# Altium schematic sheet Power.SchDoc
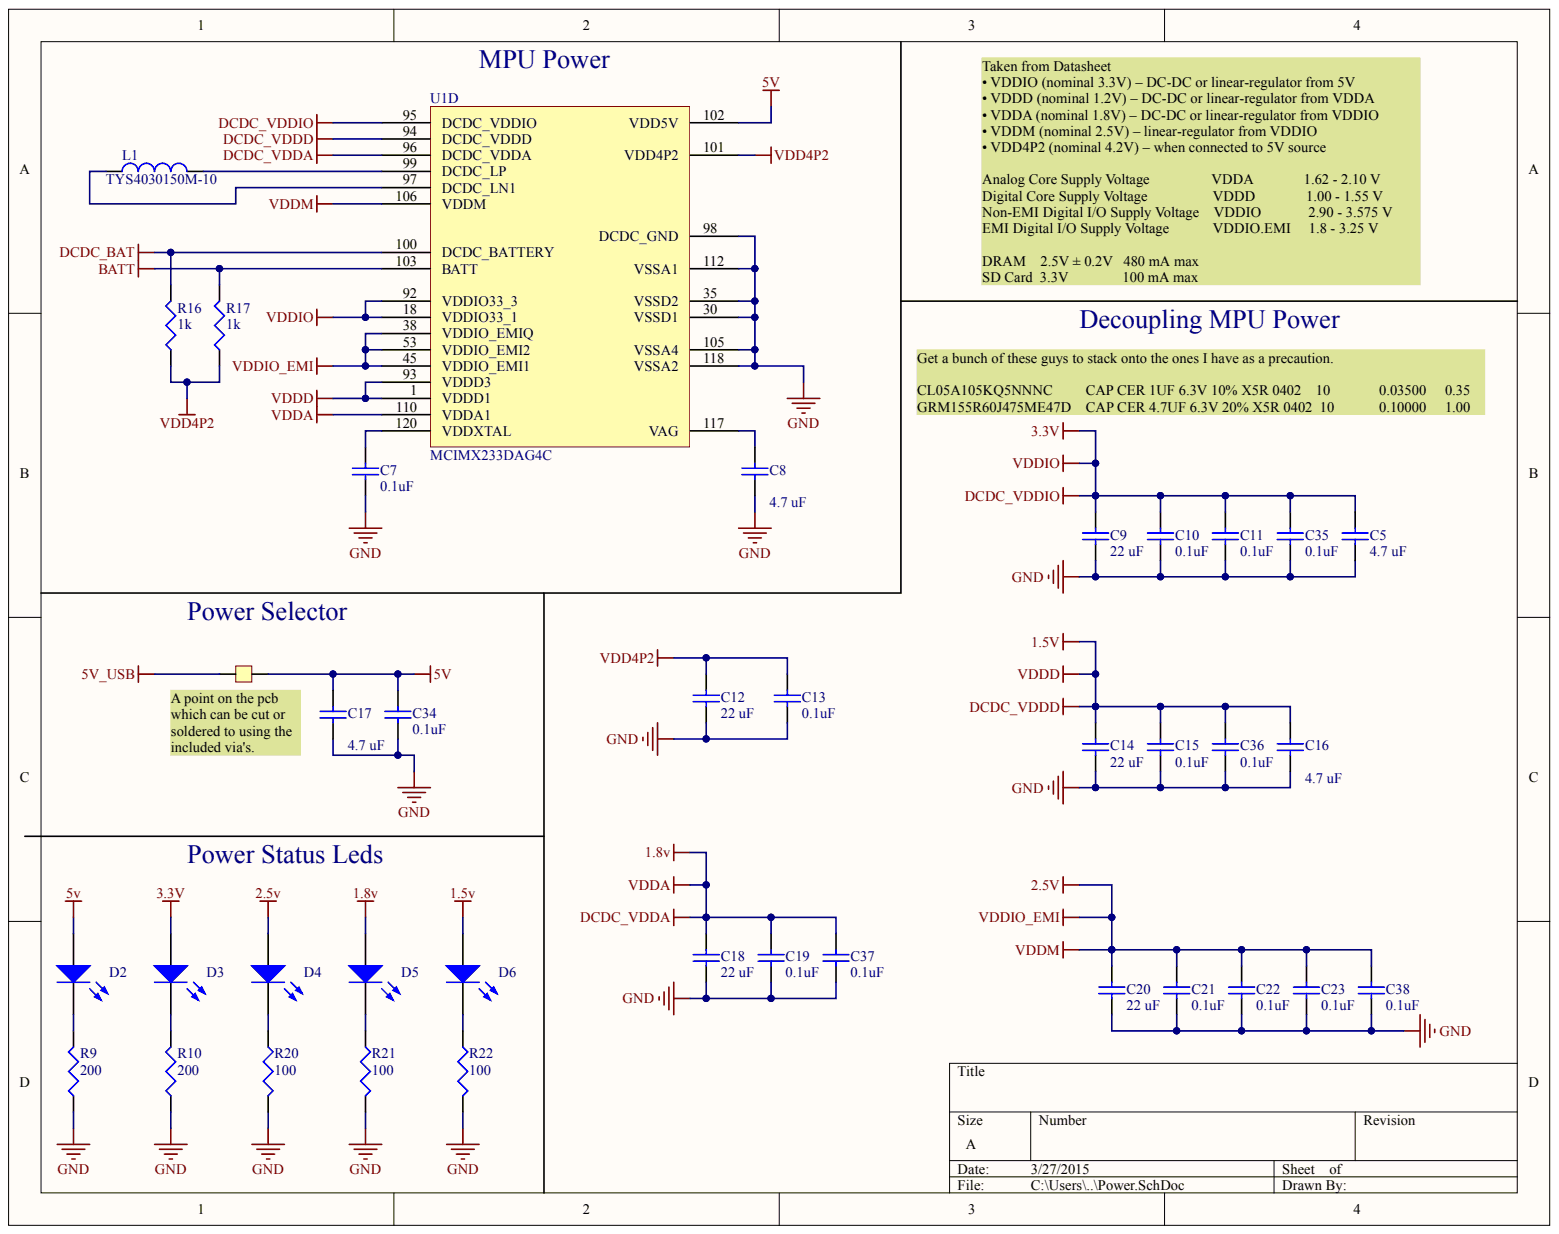
[diagram p0: Power.SchDoc]

## Parts
C5  GRM155R60J475ME47D  value 4.7 uF  [0402]
C7  value 0.1uF  [0402]  (hidden comment: CL05B104KQ5NNNC)
C8  GRM155R60J475ME47D  value 4.7 uF  [0402]
C9  value 22 uF  [0805_GRM21]  (hidden comment: GRM21BR60J226ME39L)
C10  value 0.1uF  [0402]  (hidden comment: CL05B104KQ5NNNC)
C11  value 0.1uF  [0402]  (hidden comment: CL05B104KQ5NNNC)
C12  value 22 uF  [0805_GRM21]  (hidden comment: GRM21BR60J226ME39L)
C13  value 0.1uF  [0402]  (hidden comment: CL05B104KQ5NNNC)
C14  value 22 uF  [0805_GRM21]  (hidden comment: GRM21BR60J226ME39L)
C15  value 0.1uF  [0402]  (hidden comment: CL05B104KQ5NNNC)
C16  GRM155R60J475ME47D  value 4.7 uF  [0402]
C17  GRM155R60J475ME47D  value 4.7 uF  [0402]
C18  value 22 uF  [0805_GRM21]  (hidden comment: GRM21BR60J226ME39L)
C19  value 0.1uF  [0402]  (hidden comment: CL05B104KQ5NNNC)
C20  value 22 uF  [0805_GRM21]  (hidden comment: GRM21BR60J226ME39L)
C21  value 0.1uF  [0402]  (hidden comment: CL05B104KQ5NNNC)
C22  value 0.1uF  [0402]  (hidden comment: CL05B104KQ5NNNC)
C23  value 0.1uF  [0402]  (hidden comment: CL05B104KQ5NNNC)
C34  value 0.1uF  [0402]  (hidden comment: CL05B104KQ5NNNC)
C35  value 0.1uF  [0402]  (hidden comment: CL05B104KQ5NNNC)
C36  value 0.1uF  [0402]  (hidden comment: CL05B104KQ5NNNC)
C37  value 0.1uF  [0402]  (hidden comment: CL05B104KQ5NNNC)
C38  value 0.1uF  [0402]  (hidden comment: CL05B104KQ5NNNC)
D2  LSQ976-Z  [LSQ976-Z]  (value not drawn)
D3  LSQ976-Z  [LSQ976-Z]  (value not drawn)
D4  LSQ976-Z  [LSQ976-Z]  (value not drawn)
D5  LSQ976-Z  [LSQ976-Z]  (value not drawn)
D6  LSQ976-Z  [LSQ976-Z]  (value not drawn)
L1  TYS4030150M-10  [TYS4030150M-10]
P5  CutPointet 2x  [CutPoint-VIA-medium]  (value not drawn)
R9  value 200  [J1-0603]  (hidden comment: MCR03ERTJ201)
R10  value 200  [J1-0603]  (hidden comment: MCR03ERTJ201)
R16  value 1k  [J1-0603]  (hidden comment: MCR03ERTF1001)
R17  value 1k  [J1-0603]  (hidden comment: MCR03ERTF1001)
R20  value 100  [J1-0603]  (hidden comment: RC0603JR-07100RL)
R21  value 100  [J1-0603]  (hidden comment: RC0603JR-07100RL)
R22  value 100  [J1-0603]  (hidden comment: RC0603JR-07100RL)
U1  MCIMX233DAG4C  [TSQFP40P1600X1600X160-128N]  (part D)

## Nets
1.5V: C14.2 C15.1 C16.2 C36.1 D6.A U1.1(VDDD1) U1.93(VDDD3) U1.94(DCDC_VDDD)
1.8V: C18.2 C19.1 C37.1 D5.A U1.96(DCDC_VDDA) U1.110(VDDA1)
2.5V: C20.2 C21.1 C22.1 C23.1 C38.1 D4.A U1.38(VDDIO_EMIQ) U1.45(VDDIO_EMI1) U1.53(VDDIO_EMI2) U1.106(VDDM)
3.3V: C5.2 C9.2 C10.1 C11.1 C35.1 D3.A U1.18(VDDIO33_1) U1.92(VDDIO33_3) U1.95(DCDC_VDDIO)
5V: C17.2 C34.1 D2.A P5.1 U1.102(VDD5V)
5V_USB: P5.2
BATT: R17.2 U1.103(BATT)
DCDC_BAT: R16.2 U1.100(DCDC_BATTERY)
GND: C5.1 C7.2 C8.1 C9.1 C10.2 C11.2 C12.1 C13.2 C14.1 C15.2 C16.1 C17.1 C18.1 C19.2 C20.1 C21.2 C22.2 C23.2 C34.2 C35.2 C36.2 C37.2 C38.2 R9.2 R10.2 R20.2 R21.2 R22.2 U1.30(VSSD1) U1.35(VSSD2) U1.98(DCDC_GND) U1.105(VSSA4) U1.112(VSSA1) U1.118(VSSA2)
VDD4P2: C12.2 C13.1 R16.1 R17.1 U1.101(VDD4P2)
NetC7_1: C7.1 U1.120(VDDXTAL)
NetC8_2: C8.2 U1.117(VAG)
NetD2_C: D2.C R9.1
NetD3_C: D3.C R10.1
NetD4_C: D4.C R20.1
NetD5_C: D5.C R21.1
NetD6_C: D6.C R22.1
NetL1_1: L1.1 U1.97(DCDC_LN1)
NetL1_2: L1.2 U1.99(DCDC_LP)

## Library data of the parts
C5 C8 C16 C17 = GRM155R60J475ME47D: CAP CER 4.7UF 6.3V 20% X5R 0402; Component Kind: Standard; LatestRevisionDate: 29-May-2009; LatestRevisionNote: IPC-7351 Footprint Added.; PackageDescription: Chip Capacitor; PackageReference: C1206; Published: 8-Jun-2000; Signal Integrity: Cap Semi; Simulation: CAP; Supplier 1: Digi-Key; Supplier Part Number 1: 490-5915-1-ND; Library Name: Linux_Board_SCHEM_LIB.SchLib; Publisher: Altium Limited
  C17: Value: 4.7 uF
C7 C10 C11 C13 C15 C19 C21-C23 C34-C38 = CL05B104KQ5NNNC: Cap Ceramic 0.1uF 6.3V X7R 10% SMD 0402; Component Kind: Standard; LatestRevisionDate: 29-May-2009; LatestRevisionNote: IPC-7351 Footprint Added.; PackageDescription: Chip Capacitor; PackageReference: C1206; Published: 8-Jun-2000; Signal Integrity: Cap Semi; Simulation: CAP; Supplier 1: Arrow; Supplier 2: Digi-Key; Supplier Part Number 1: c0Ft5huTF1PnwzXLA59QPYxRs3E3aghew1LSAii7cAMZVywxIBNyoAQstvnyfZZ0; Supplier Part Number 2: 1276-1511-1-ND; Library Name: Linux_Board_SCHEM_LIB.SchLib; Publisher: Altium Limited
C9 C12 C14 C18 C20 = GRM21BR60J226ME39L: CAP CER 22UF 6.3V 20% X5R 0805; Component Kind: Standard; LatestRevisionDate: 29-May-2009; LatestRevisionNote: IPC-7351 Footprint Added.; PackageDescription: Chip Capacitor; PackageReference: C1206; Published: 8-Jun-2000; Signal Integrity: Cap Semi; Simulation: CAP; Supplier 1: Digi-Key; Supplier Part Number 1: 490-1719-1-ND; Library Name: Linux_Board_SCHEM_LIB.SchLib; Publisher: Altium Limited
D2-D6 = LSQ976-Z: OSRAM - LED, SMD, RED, 180MCD, 633NM; Component Kind: Standard; Signal Integrity: Guessed Model; Supplier 1: Mouser; Supplier 2: Newark; Supplier Part Number 1: N/A; Supplier Part Number 2: 05M0541; Library Name: Linux_Board_SCHEM_LIB.SchLib
L1 = TYS4030150M-10: FIXED IND 15UH 1.11A 190 MOHM; Component Kind: Standard; Library Name: Linux_Board_SCHEM_LIB.SchLib
P5 = CutPointet 2x: 2 net point that can be cut and soldered; Component Kind: Standard; LatestRevisionDate: 17-Jul-2002; LatestRevisionNote: Re-released for DXP Platform.; Signal Integrity: Connector; Library Name: Linux_Board_SCHEM_LIB.SchLib; Publisher: Altium Limited
R9 R10 = MCR03ERTJ201: RES 200 OHM 1/10W 5% 0603 SMD; Component Kind: Standard; LatestRevisionDate: 29-May-2009; LatestRevisionNote: IPC-7351 Footprint Added.; PackageDescription: Chip Resistor; PackageReference: J1-0603; Published: 8-Jun-2000; Signal Integrity: Res; Simulation: RESISTOR; Supplier 1: Digi-Key; Supplier Part Number 1: RHM200CGCT-ND; Library Name: Linux_Board_SCHEM_LIB.SchLib; Publisher: Altium Limited
R16 R17 = MCR03ERTF1001: RES SMD 1K OHM 1% 1/10W 0603; Component Kind: Standard; LatestRevisionDate: 29-May-2009; LatestRevisionNote: IPC-7351 Footprint Added.; PackageDescription: Chip Resistor; PackageReference: J1-0603; Published: 8-Jun-2000; Signal Integrity: Res; Simulation: RESISTOR; Supplier 1: Digi-Key; Supplier Part Number 1: P100KGCT-ND; Library Name: Linux_Board_SCHEM_LIB.SchLib; Publisher: Altium Limited
R20-R22 = RC0603JR-07100RL: RES SMD 100 OHM 5% 1/10W 0603; Component Kind: Standard; LatestRevisionDate: 29-May-2009; LatestRevisionNote: IPC-7351 Footprint Added.; PackageDescription: Chip Resistor; PackageReference: J1-0603; Published: 8-Jun-2000; Signal Integrity: Res; Simulation: RESISTOR; Supplier 1: Digi-Key; Supplier Part Number 1: 311-100GRCT-ND; Library Name: Linux_Board_SCHEM_LIB.SchLib; Publisher: Altium Limited
U1 = MX233DAG4C: IC MPU I.MX23 454MHZ 128LQFP; Component Kind: Standard; Supplier 1: Digi-Key; Supplier Part Number 1: MCIMX233DAG4C-ND; Library Name: Linux_Board_SCHEM_LIB.SchLib
